# Altium schematic sheet P05_STM32MP1_PART_B_BOOT_.SchDoc(P05_STM32MP1_PART_B_BOOT_)
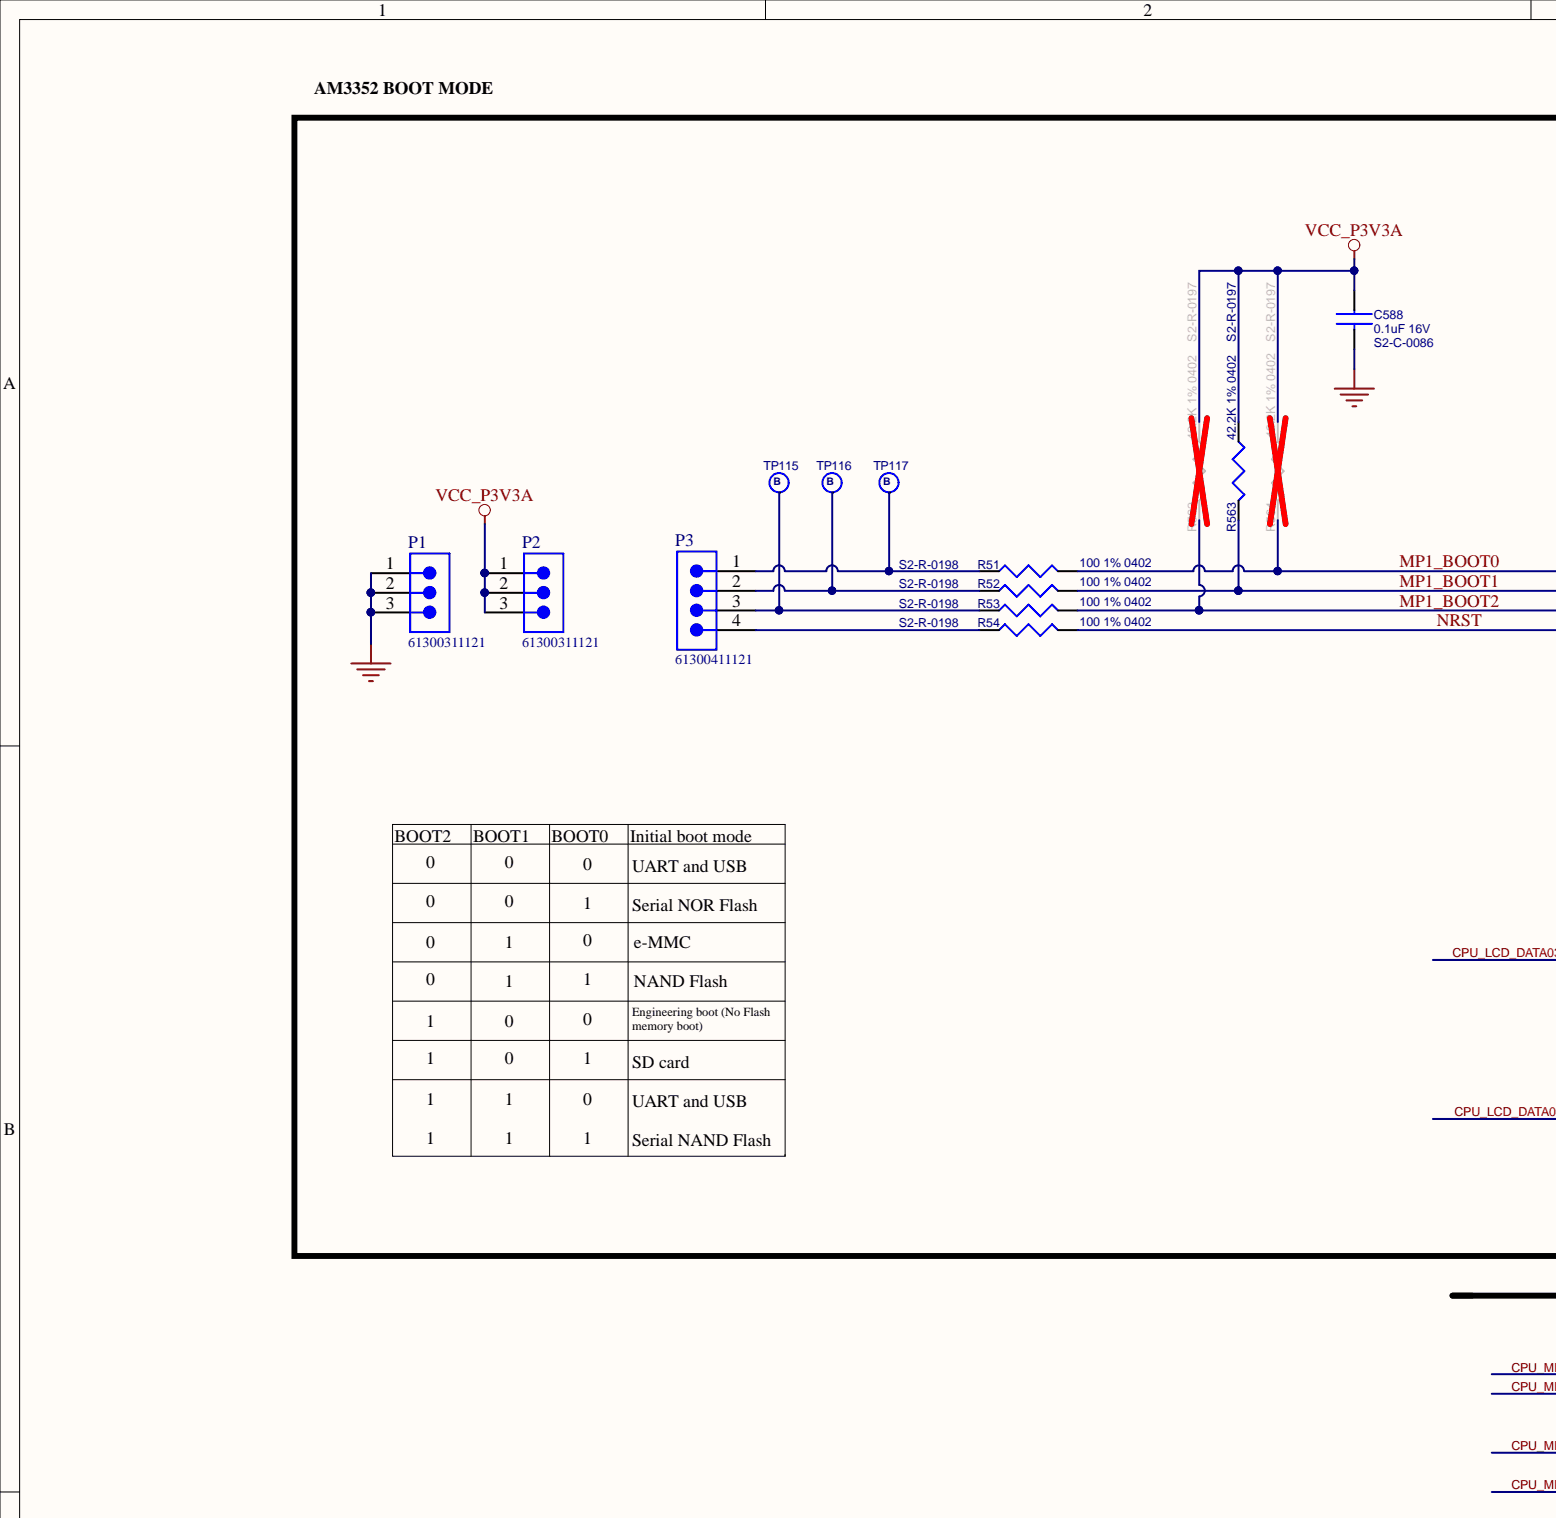
[diagram p0: P05_STM32MP1_PART_B_BOOT_.SchDoc, top left]
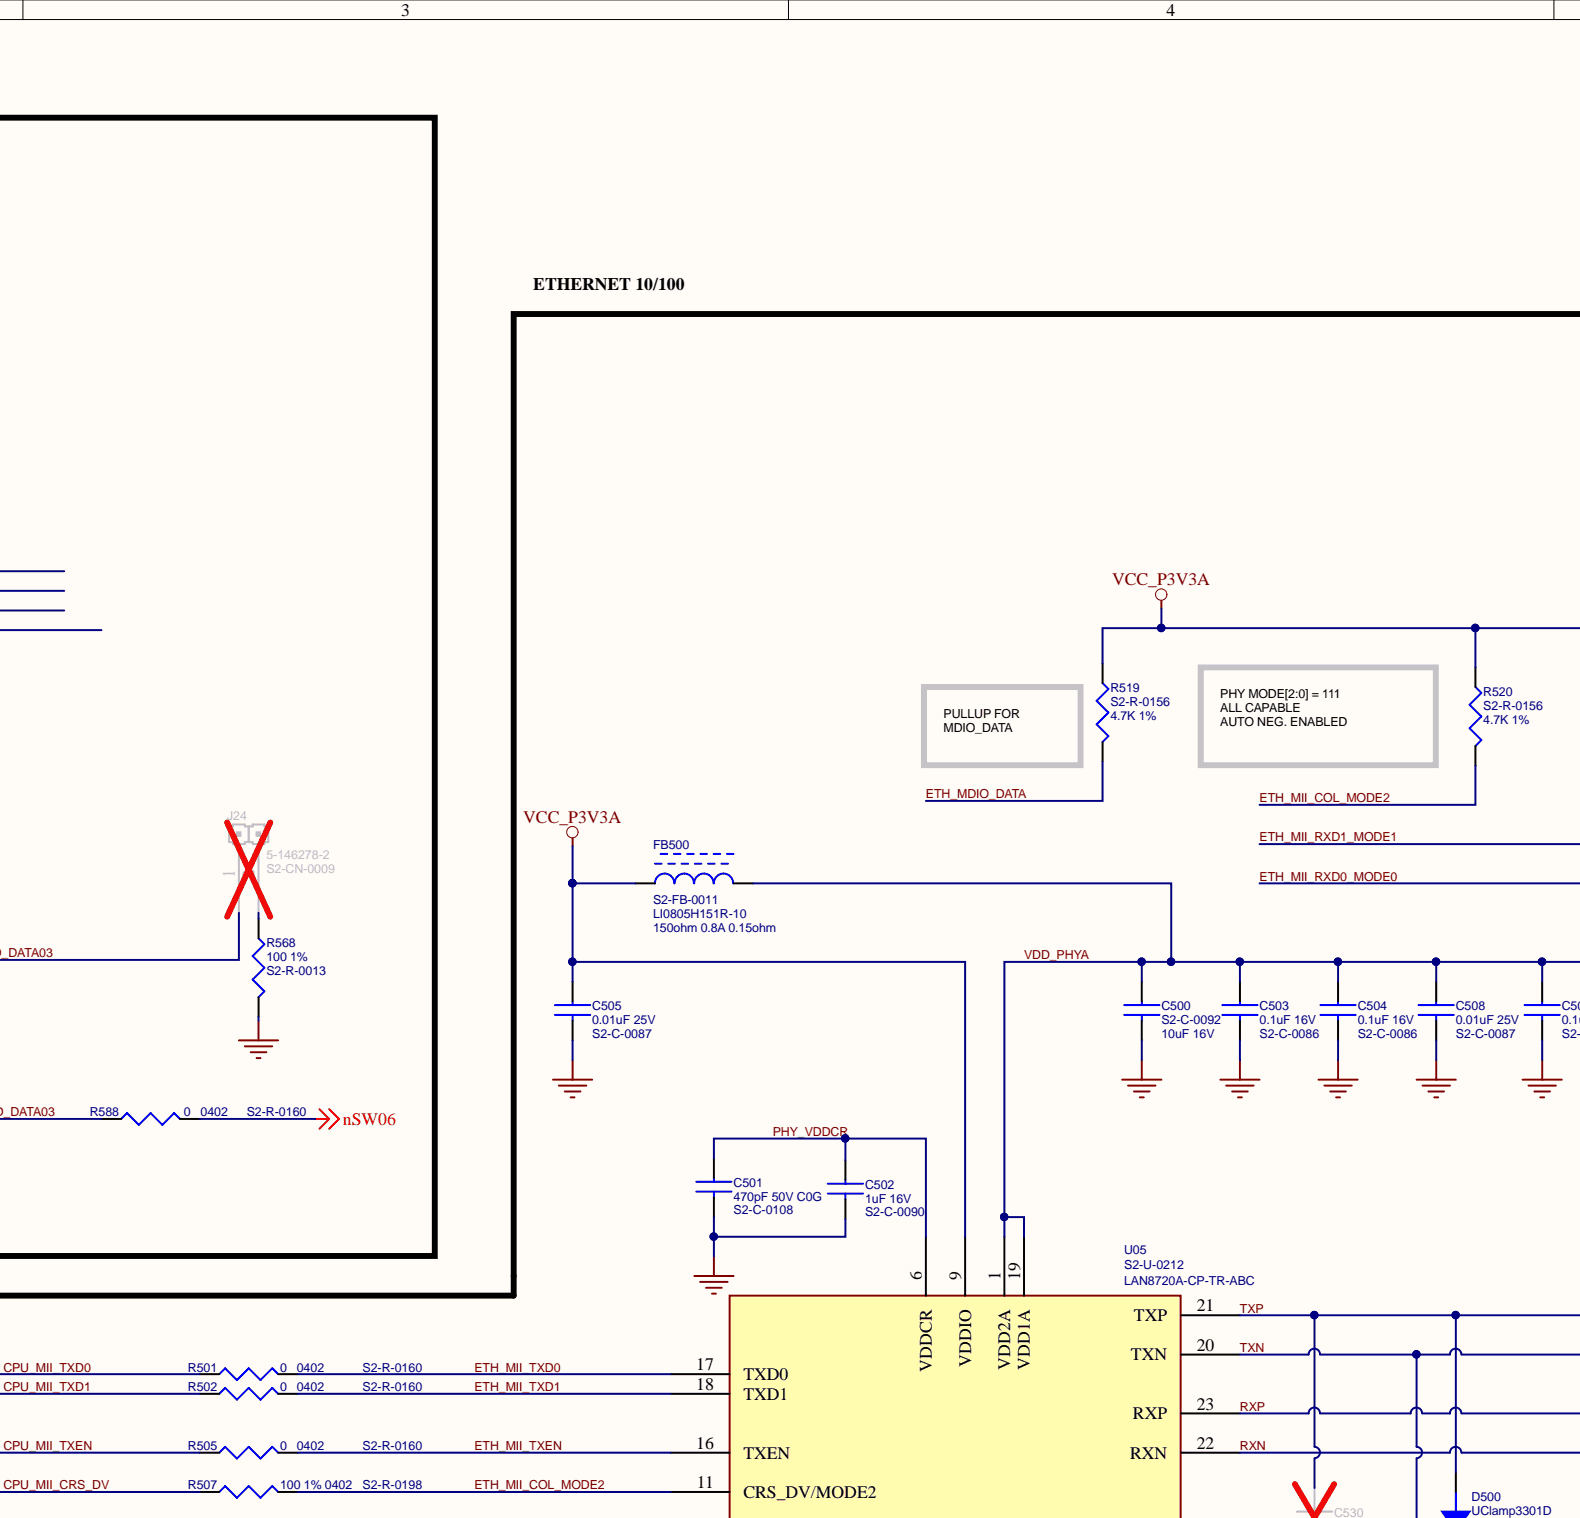
[diagram p1: P05_STM32MP1_PART_B_BOOT_.SchDoc, top centre]
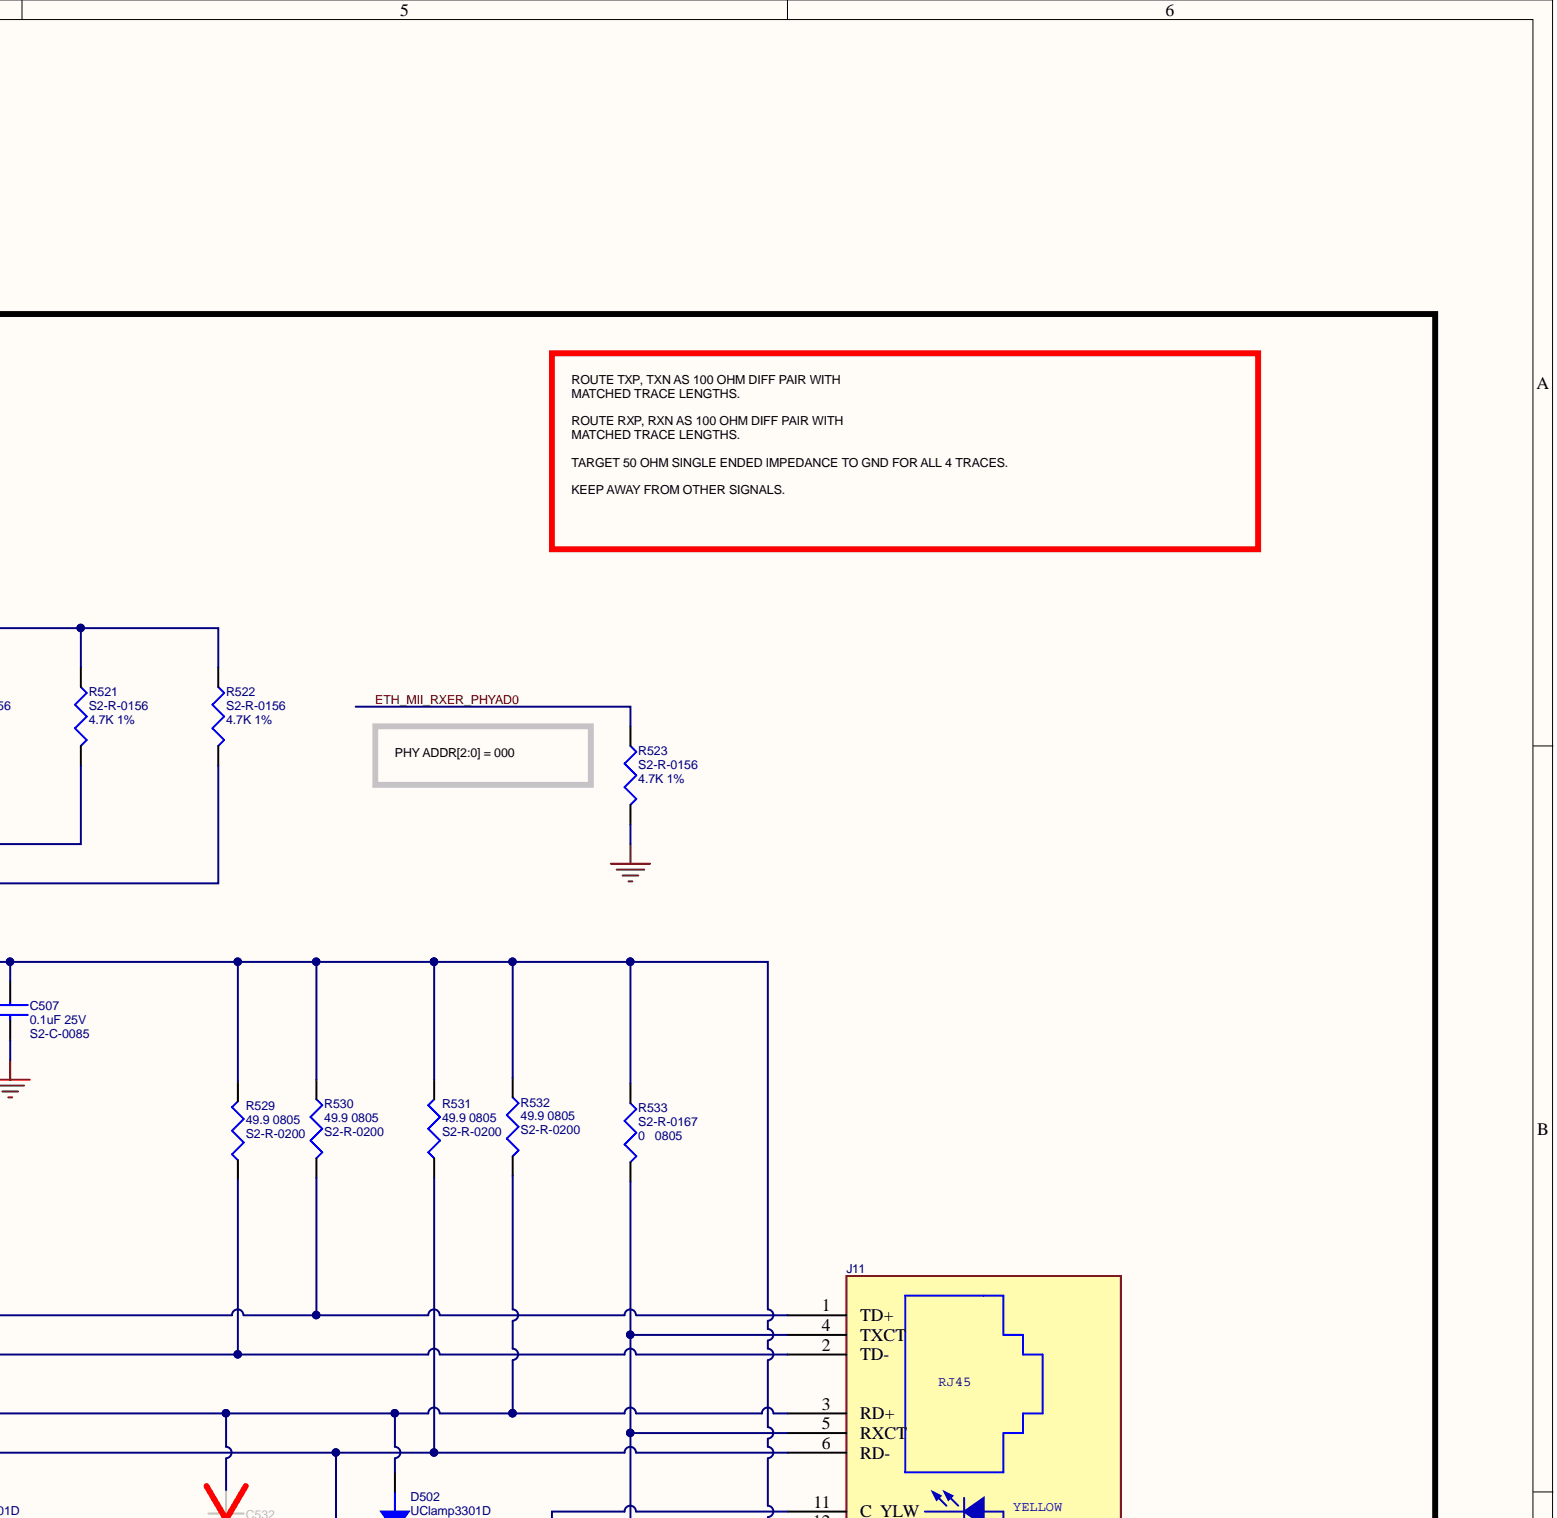
[diagram p2: P05_STM32MP1_PART_B_BOOT_.SchDoc, top right]
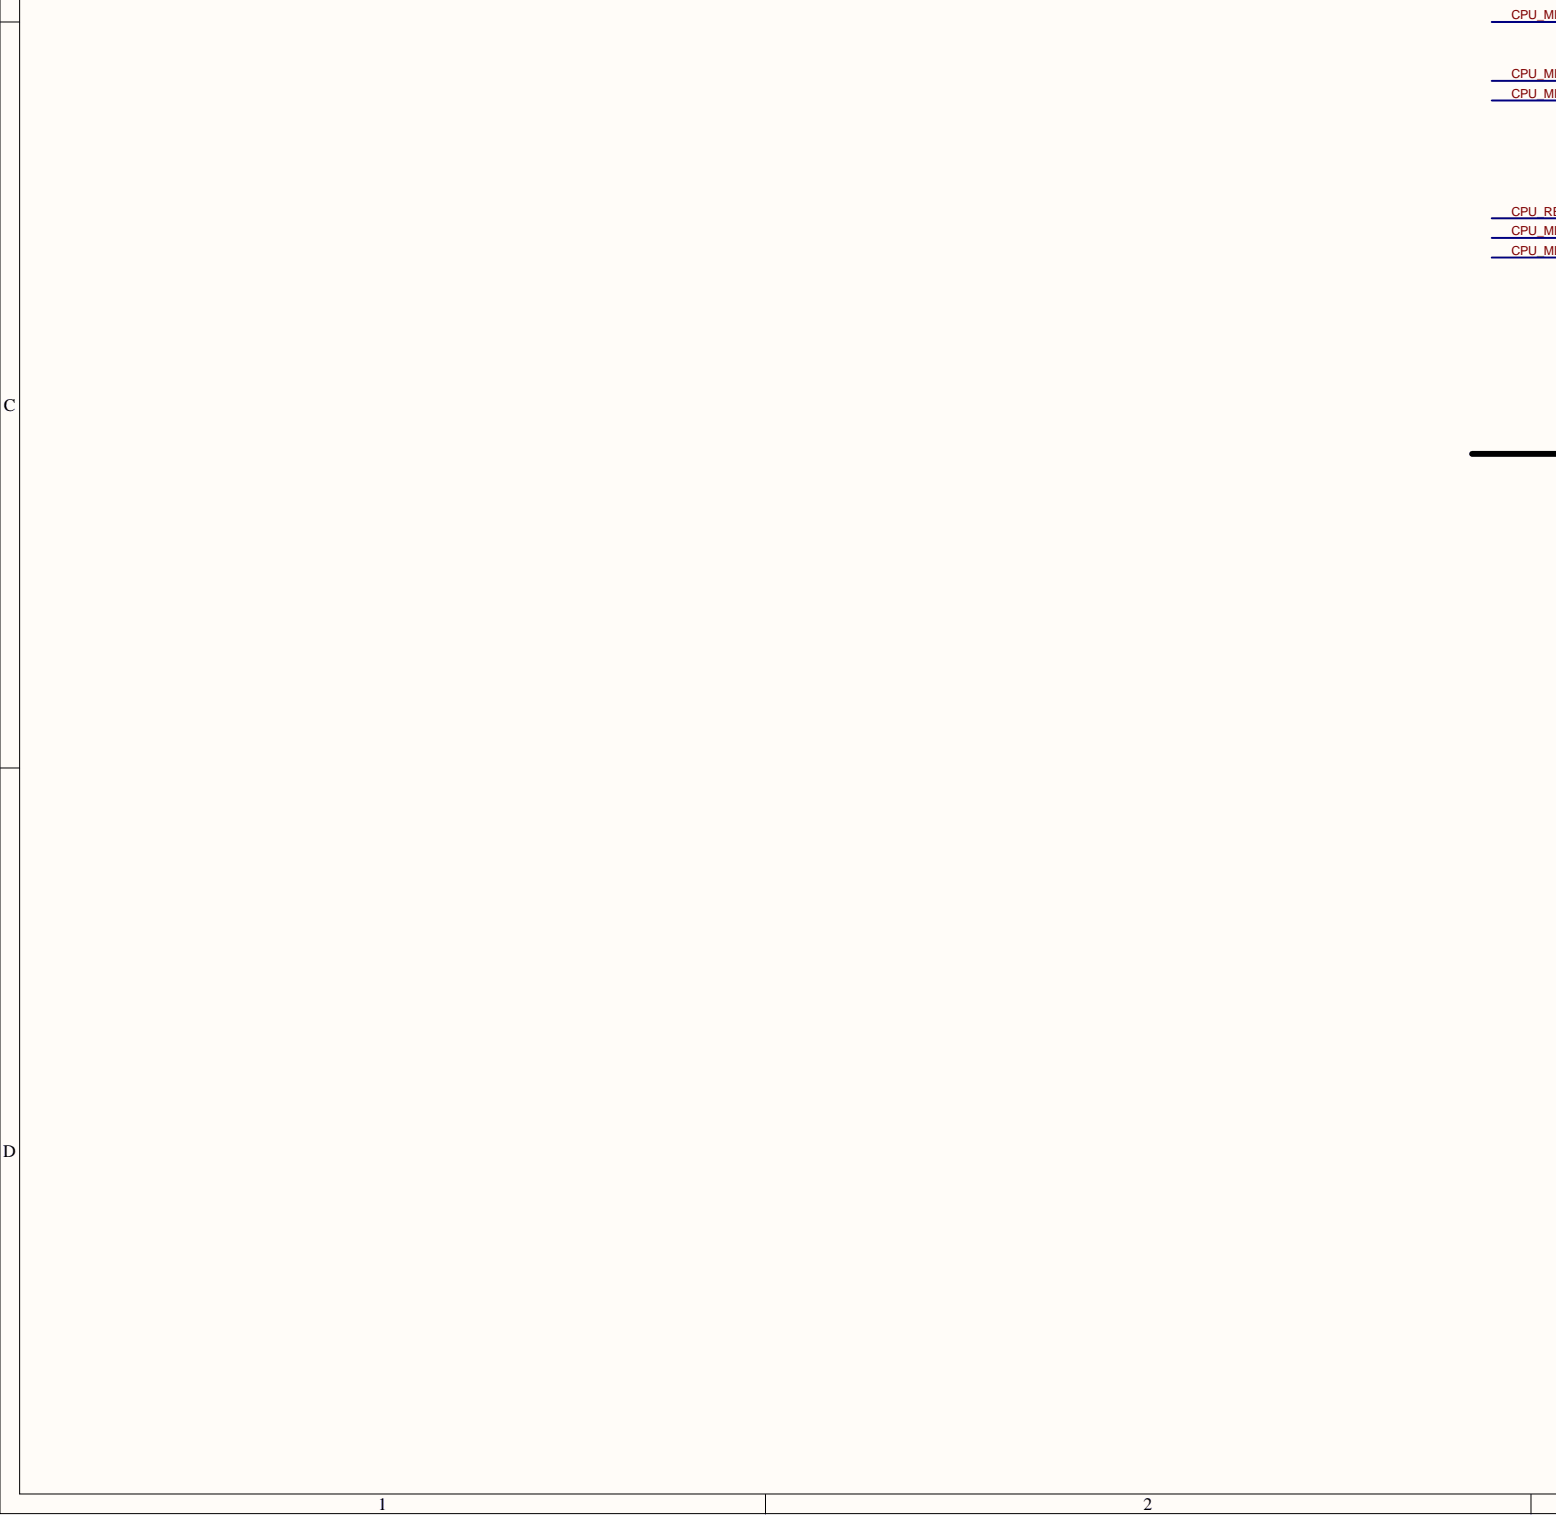
[diagram p3: P05_STM32MP1_PART_B_BOOT_.SchDoc, bottom left]
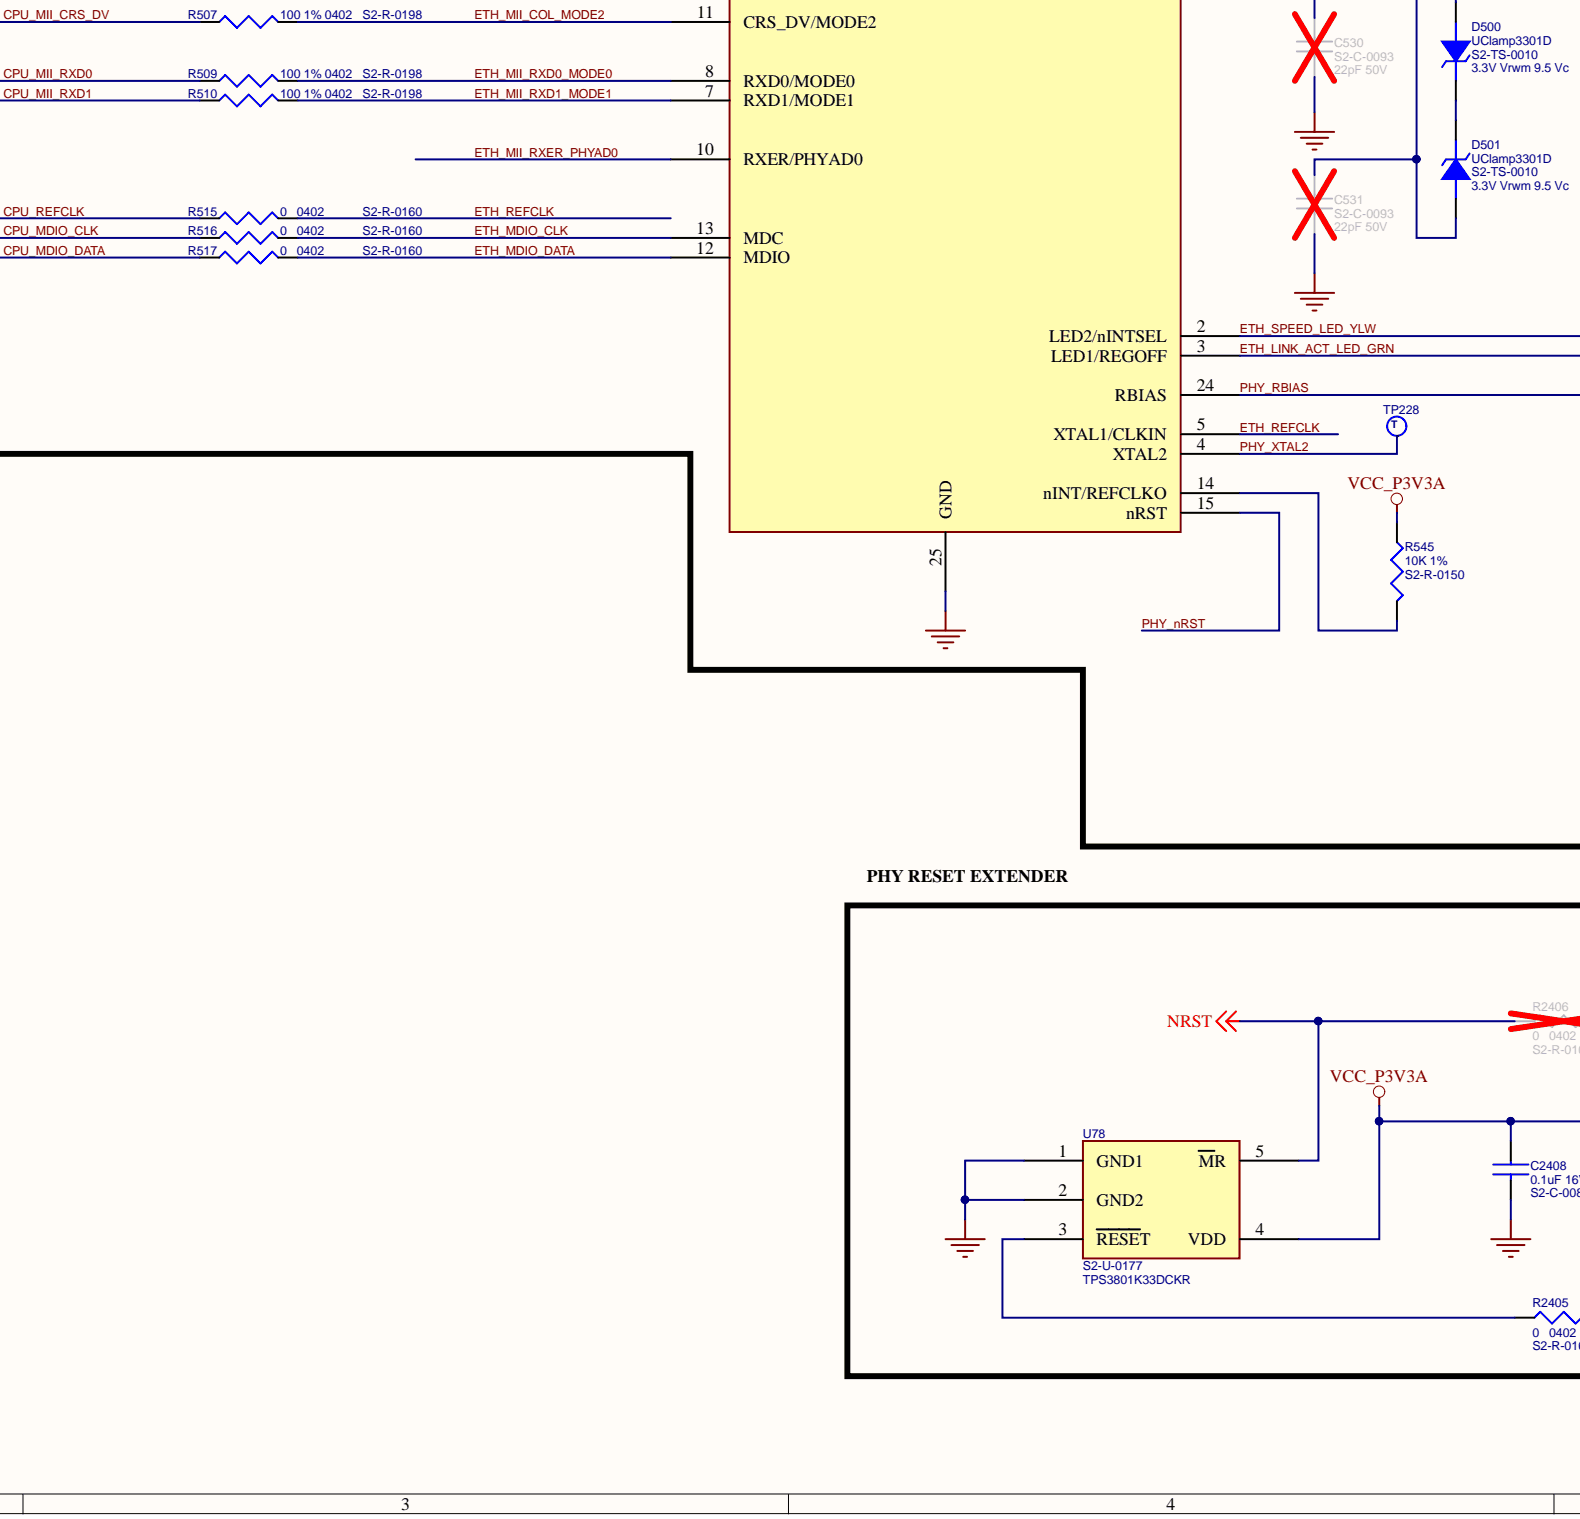
[diagram p4: P05_STM32MP1_PART_B_BOOT_.SchDoc, bottom centre]
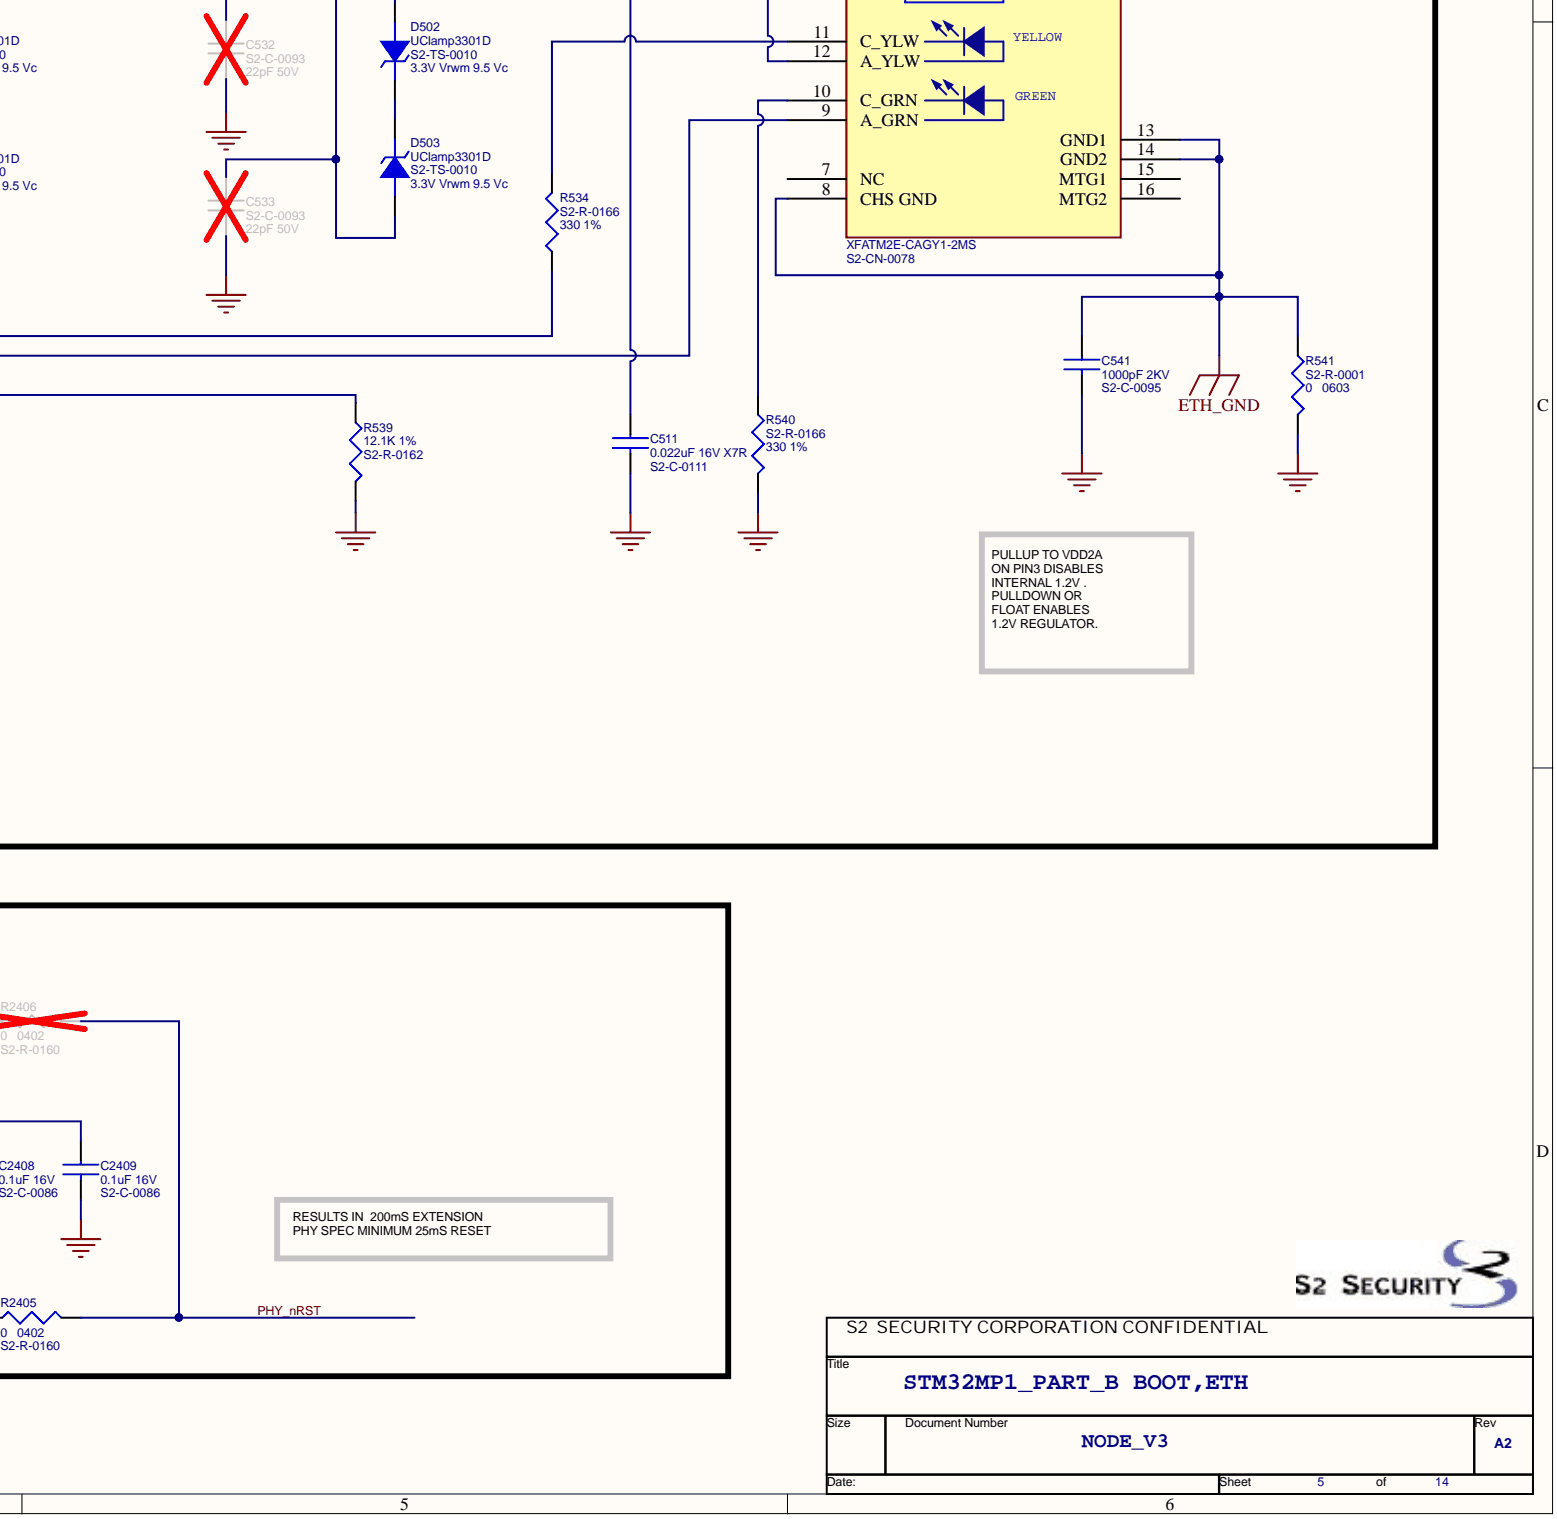
[diagram p5: P05_STM32MP1_PART_B_BOOT_.SchDoc, bottom right]

## Parts
C500  S2_PN: S2-C-0092  [CAPC2012X145N]  (hidden comment: C_10U_16V_0805)
C501  S2_PN: S2-C-0108  [CAPC1005X55N]  (hidden comment: C_470P_50V_0402_C0G)
C502  S2_PN: S2-C-0090  DESC: 1uF 16V  [CAPC1005X55N]  (hidden comment: C_1U_16V_0402)
C503  S2_PN: S2-C-0086  DESC: 0.1uF 16V  [CAPC1005X55N]  (hidden comment: C_0U1_16V_0402)
C504  S2_PN: S2-C-0086  DESC: 0.1uF 16V  [CAPC1005X55N]  (hidden comment: C_0U1_16V_0402)
C505  S2_PN: S2-C-0087  DESC: 0.01uF 25V  [CAPC1005X55N]  (hidden comment: C_0U01_25V_0402)
C507  S2_PN: S2-C-0085  DESC: 0.1uF 25V  [CAPC1608X90N]  (hidden comment: C_0U1_25V_0603)
C508  S2_PN: S2-C-0087  DESC: 0.01uF 25V  [CAPC1005X55N]  (hidden comment: C_0U01_25V_0402)
C511  S2_PN: S2-C-0111  [CAPC1005X55N]  (hidden comment: C_0U022_16V_0402)
C530  S2_PN: S2-C-0093  DESC: 22pF 50V  [CAPC1005X55N]  (hidden comment: C_22P_50V_0402_C0G)
C531  S2_PN: S2-C-0093  DESC: 22pF 50V  [CAPC1005X55N]  (hidden comment: C_22P_50V_0402_C0G)
C532  S2_PN: S2-C-0093  DESC: 22pF 50V  [CAPC1005X55N]  (hidden comment: C_22P_50V_0402_C0G)
C533  S2_PN: S2-C-0093  DESC: 22pF 50V  [CAPC1005X55N]  (hidden comment: C_22P_50V_0402_C0G)
C541  S2_PN: S2-C-0095  DESC: 1000pF 2KV  [CAPC3216X180N]  (hidden comment: C_1000P_2000V_1206)
C588  S2_PN: S2-C-0086  DESC: 0.1uF 16V  [CAPC1005X55N]  (hidden comment: C_0U1_16V_0402)
C2408  S2_PN: S2-C-0086  DESC: 0.1uF 16V  [CAPC1005X55N]  (hidden comment: C_0U1_16V_0402)
C2409  S2_PN: S2-C-0086  DESC: 0.1uF 16V  [CAPC1005X55N]  (hidden comment: C_0U1_16V_0402)
D500  S2_PN: S2-TS-0010  DESC: UClamp3301D  [SOD2613X117N]  (hidden comment: D_UCLAMP3301D)
D501  S2_PN: S2-TS-0010  DESC: UClamp3301D  [SOD2613X117N]  (hidden comment: D_UCLAMP3301D)
D502  S2_PN: S2-TS-0010  DESC: UClamp3301D  [SOD2613X117N]  (hidden comment: D_UCLAMP3301D)
D503  S2_PN: S2-TS-0010  DESC: UClamp3301D  [SOD2613X117N]  (hidden comment: D_UCLAMP3301D)
FB500  S2_PN: S2-FB-0011  DESC: LI0805H151R-10  ATTR2: 150ohm 0.8A 0.15ohm  [FB0805]  (hidden comment: FB_0A8_150OHM_0805_DC_150mOHM)
J11  S2_PN: S2-CN-0078  DESC: XFATM2E-CAGY1-2MS  [CONN_XFATM2E-CAGY1-2MS]  (hidden comment: CN_RJ45_XFATM2E-CAGY1-2MS)
J24  S2_PN: S2-CN-0009  PKG: 5-146278-2  [Conn_5-146278-2]  (hidden comment: CN_100_2POS_STR_UNSHR)
P1  61300311121  [61300311121]
P2  61300311121  [61300311121]
P3  61300411121  [61300411121]
R51  S2_PN: S2-R-0198  DESC: 100 1% 0402  [RESC1005X40N]  (hidden comment: R_100_0402)
R52  S2_PN: S2-R-0198  DESC: 100 1% 0402  [RESC1005X40N]  (hidden comment: R_100_0402)
R53  S2_PN: S2-R-0198  DESC: 100 1% 0402  [RESC1005X40N]  (hidden comment: R_100_0402)
R54  S2_PN: S2-R-0198  DESC: 100 1% 0402  [RESC1005X40N]  (hidden comment: R_100_0402)
R501  S2_PN: S2-R-0160  DESC: 0   0402  [RESC1005X40N]  (hidden comment: R_0_0402)
R502  S2_PN: S2-R-0160  DESC: 0   0402  [RESC1005X40N]  (hidden comment: R_0_0402)
R505  S2_PN: S2-R-0160  DESC: 0   0402  [RESC1005X40N]  (hidden comment: R_0_0402)
R507  S2_PN: S2-R-0198  DESC: 100 1% 0402  [RESC1005X40N]  (hidden comment: R_100_0402)
R509  S2_PN: S2-R-0198  DESC: 100 1% 0402  [RESC1005X40N]  (hidden comment: R_100_0402)
R510  S2_PN: S2-R-0198  DESC: 100 1% 0402  [RESC1005X40N]  (hidden comment: R_100_0402)
R515  S2_PN: S2-R-0160  DESC: 0   0402  [RESC1005X40N]  (hidden comment: R_0_0402)
R516  S2_PN: S2-R-0160  DESC: 0   0402  [RESC1005X40N]  (hidden comment: R_0_0402)
R517  S2_PN: S2-R-0160  DESC: 0   0402  [RESC1005X40N]  (hidden comment: R_0_0402)
R519  S2_PN: S2-R-0156  DESC: 4.7K 1%  [RESC1005X40N]  (hidden comment: R_4K7_0402)
R520  S2_PN: S2-R-0156  DESC: 4.7K 1%  [RESC1005X40N]  (hidden comment: R_4K7_0402)
R521  S2_PN: S2-R-0156  DESC: 4.7K 1%  [RESC1005X40N]  (hidden comment: R_4K7_0402)
R522  S2_PN: S2-R-0156  DESC: 4.7K 1%  [RESC1005X40N]  (hidden comment: R_4K7_0402)
R523  S2_PN: S2-R-0156  DESC: 4.7K 1%  [RESC1005X40N]  (hidden comment: R_4K7_0402)
R529  S2_PN: S2-R-0200  DESC: 49.9 0805  [RESC2012X50N]  (hidden comment: R_49R9_0805)
R530  S2_PN: S2-R-0200  DESC: 49.9 0805  [RESC2012X50N]  (hidden comment: R_49R9_0805)
R531  S2_PN: S2-R-0200  DESC: 49.9 0805  [RESC2012X50N]  (hidden comment: R_49R9_0805)
R532  S2_PN: S2-R-0200  DESC: 49.9 0805  [RESC2012X50N]  (hidden comment: R_49R9_0805)
R533  S2_PN: S2-R-0167  DESC: 0   0805  [RESC2012X50N]  (hidden comment: R_0_0805)
R534  S2_PN: S2-R-0166  DESC: 330 1%  [RESC1005X40N]  (hidden comment: R_330_0402)
R539  S2_PN: S2-R-0162  DESC: 12.1K 1%  [RESC1005X40N]  (hidden comment: R_12K1_0402)
R540  S2_PN: S2-R-0166  DESC: 330 1%  [RESC1005X40N]  (hidden comment: R_330_0402)
R541  S2_PN: S2-R-0001  DESC: 0   0603  [RESC1608X55N]  (hidden comment: R_0_0603)
R545  S2_PN: S2-R-0150  DESC: 10K 1%  [RESC1005X40N]  (hidden comment: R_10K_0402)
R562  DESC: 42.2K 1% 0402  [RESC1005X40N]  (hidden comment: R_42K2_0402)
R563  DESC: 42.2K 1% 0402  [RESC1005X40N]  (hidden comment: R_42K2_0402)
R564  DESC: 42.2K 1% 0402  [RESC1005X40N]  (hidden comment: R_42K2_0402)
R568  S2_PN: S2-R-0013  DESC: 100 1%  [RESC1608X55N]  (hidden comment: R_100_0603)
R588  S2_PN: S2-R-0160  DESC: 0   0402  [RESC1005X40N]  (hidden comment: R_0_0402)
R2405  S2_PN: S2-R-0160  DESC: 0   0402  [RESC1005X40N]  (hidden comment: R_0_0402)
R2406  S2_PN: S2-R-0160  DESC: 0   0402  [RESC1005X40N]  (hidden comment: R_0_0402)
TP115  TP_SMT_TEST_POINT_BOTTOM  [TP35_SMT]  (value not drawn)
TP116  TP_SMT_TEST_POINT_BOTTOM  [TP35_SMT]  (value not drawn)
TP117  TP_SMT_TEST_POINT_BOTTOM  [TP35_SMT]  (value not drawn)
TP228  TP_SMT_TEST_POINT_TOP  [TP35_SMT]  (value not drawn)
U05  S2_PN: S2-U-0212  DESC: LAN8720A-CP-TR-ABC  [QFN50P400X400X100-25N]  (hidden comment: U_LAN8720A_CP)
U78  S2_PN: S2-U-0177  DESC: TPS3801K33DCKR  [SOT65x210x140x110-5N]  (hidden comment: U_TPS3801K33DCKR_SUPERVISOR)

## Nets
ETH_GND: C541.1 J11.8(CHS_GND) J11.13(GND1) J11.14(GND2) R541.1
GND: C500.2 C501.2 C502.2 C503.2 C504.2 C505.2 C507.2 C508.2 C511.2 C530.2 C531.2 C532.2 C533.2 C541.2 C588.2 C2408.2 C2409.2 P1.1 P1.2 P1.3 R523.2 R539.2 R540.2 R541.2 R568.2 U05.25(GND) U78.1(GND1) U78.2(GND2)
nSW06: R588.2
VCC_P3V3A: C505.1 C588.1 C2408.1 C2409.1 FB500.1 P2.1 P2.2 P2.3 R519.1 R520.1 R521.1 R522.1 R545.1 R562.1 R563.1 R564.1 U05.9(VDDIO) U78.4(VDD)
CPU_LCD_DATA03: J24.1 R588.1
CPU_MDIO_CLK: R516.1
CPU_MDIO_DATA: R517.1
CPU_MII_CRS_DV: R507.1
CPU_MII_RXD0: R509.1
CPU_MII_RXD1: R510.1
CPU_MII_TXD0: R501.1
CPU_MII_TXD1: R502.1
CPU_MII_TXEN: R505.1
CPU_REFCLK: R515.1
ETH_LINK_ACT_LED_GRN: J11.9(A_GRN) U05.3(LED1/REGOFF)
ETH_MDIO_CLK: R516.2 U05.13(MDC)
ETH_MDIO_DATA: R517.2 R519.2 U05.12(MDIO)
ETH_MII_COL_MODE2: R507.2 R520.2 U05.11(CRS_DV/MODE2)
ETH_MII_RXD0_MODE0: R509.2 R522.2 U05.8(RXD0/MODE0)
ETH_MII_RXD1_MODE1: R510.2 R521.2 U05.7(RXD1/MODE1)
ETH_MII_RXER_PHYAD0: R523.1 U05.10(RXER/PHYAD0)
ETH_MII_TXD0: R501.2 U05.17(TXD0)
ETH_MII_TXD1: R502.2 U05.18(TXD1)
ETH_MII_TXEN: R505.2 U05.16(TXEN)
ETH_REFCLK: R515.2 U05.5(XTAL1/CLKIN)
ETH_SPEED_LED_YLW: R534.2 U05.2(LED2/nINTSEL)
MP1_BOOT0: R51.2 R564.2
MP1_BOOT1: R52.2 R563.2
MP1_BOOT2: R53.2 R562.2
NRST: R54.2 R2406.1 U78.5(MR)
PHY_nRST: R2405.2 R2406.2 U05.15(nRST)
PHY_RBIAS: R539.1 U05.24(RBIAS)
PHY_VDDCR: C501.1 C502.1 U05.6(VDDCR)
PHY_XTAL2: TP228.1 U05.4(XTAL2)
RXN: C533.1 D503.1 J11.6(RD-) R531.2 U05.22(RXN)
RXP: C532.1 D502.1 J11.3(RD+) R532.2 U05.23(RXP)
TXN: C531.1 D501.1 J11.2(TD-) R529.2 U05.20(TXN)
TXP: C530.1 D500.1 J11.1(TD+) R530.2 U05.21(TXP)
VDD_PHYA: C500.1 C503.1 C504.1 C507.1 C508.1 FB500.2 J11.12(A_YLW) R529.1 R530.1 R531.1 R532.1 R533.1 U05.1(VDD2A) U05.19(VDD1A)
NetC511_1: C511.1 J11.4(TXCT) J11.5(RXCT) R533.2
NetD500_2: D500.2 D501.2
NetD502_2: D502.2 D503.2
NetJ11_10: J11.10(C_GRN) R540.1
NetJ11_11: J11.11(C_YLW) R534.1
NetJ24_2: J24.2 R568.1
NetP3_1: P3.1 R51.1 TP117.1
NetP3_2: P3.2 R52.1 TP116.1
NetP3_3: P3.3 R53.1 TP115.1
NetP3_4: P3.4 R54.1
NetR545_2: R545.2 U05.14(nINT/REFCLKO)
NetR2405_1: R2405.1 U78.3(RESET)

Not connected: J11 7(NC) 15(MTG1) 16(MTG2)

## Library data of the parts
C500 = C_10U_16V_0805: PKG: CC0805IPC; DESC: 10uF 16V; ATTR: X5R 10%; Library Name: NODE_V2_M1.SCHLIB; Component Kind: Standard
C501 = C_470P_50V_0402_C0G: PKG: CC0402IPC; DESC: 470pF 50V C0G; ATTR: C0G 5%; Library Name: NODE_V2_M1.SCHLIB; Component Kind: Standard
C502 = C_1U_16V_0402: PKG: CC0402IPC; ATTR: X5R 10%; Library Name: NODE_V2_M1.SCHLIB; Component Kind: Standard
C503 C504 C588 C2408 C2409 = C_0U1_16V_0402: PKG: CC0402IPC; ATTR: X7R 10%; Library Name: NODE_V2_M1.SCHLIB; Component Kind: Standard
C505 C508 = C_0U01_25V_0402: PKG: CC0402IPC; ATTR: X7R 10%; Library Name: NODE_V2_M1.SCHLIB; Component Kind: Standard
C507 = C_0U1_25V_0603: PKG: CC0603IPC; ATTR: X7R 10%; Library Name: NODE_V2_M1.SCHLIB; Component Kind: Standard
C511 = C_0U022_16V_0402: PKG: CC0402IPC; DESC: 0.022uF 16V X7R; ATTR: X7R 10%; Library Name: NODE_V2_M1.SCHLIB; Component Kind: Standard
C530-C533 = C_22P_50V_0402_C0G: PKG: CC0402IPC; ATTR: C0G 5%; Library Name: NODE_V2_M1.SCHLIB; Component Kind: Standard
C541 = C_1000P_2000V_1206: PKG: CC1206IPC; ATTR: X7R 10%; Library Name: NODE_V2_M1.SCHLIB; Component Kind: Standard
D500-D503 = D_UCLAMP3301D: PKG: SOD-323; ATTR2: 3.3V Vrwm 9.5 Vc; ATTR: TVS 3.3V REV 9.5V CLAMP SOD323; Library Name: NODE_V2_M1.SCHLIB; Component Kind: Standard
FB500 = FB_0A8_150OHM_0805_DC_150mOHM: PKG: CC0805IPC; ATTR: FERRITE 800MA 150 OHM 0805, 0.15 OHM DC; Library Name: NODE_V2_M1.SCHLIB; Component Kind: Standard
J11 = CN_RJ45_XFATM2E-CAGY1-2MS: PKG: TH_CONN14A_RJ45; ATTR: RJ45 W/ LED, MAG, NO EMI FINGERS; Library Name: NODE_V2_M1.SCHLIB; Component Kind: Standard
J24 = CN_100_2POS_STR_UNSHR: ATTR: HEADER MALE 2POS 0.100" STRAIGHT UNSHROUDED; Library Name: NODE_V2_M1.SCHLIB; DESC: 5-146278-2; Component Kind: Standard
P1 P2 = 61300311121: WR-PHD Pin Header, THT, pitch 2.54mm, Single Row, Vertical, 3p; Manufacturer: Wurth Elektronik; Working Voltage: 250VAC; Withstanding Voltage: 500VAC; Rated Current: 3A; Pin Number: 3; Contact Resistance: 20mOhm; Row Number: Single; Published Date: May 2020; Pitch: 2.54mm; Packaging: Bag; Orientation: Vertical; Operating Temperature: -40 to +105degC; Mounting Technology: THT; Manufacturer Part Number: 61300311121; Library Name: Altium_WR-PHD (rev20a).IntLib; Gender: Pin Header; ComponentLink2URL: https://www.we-online.com/catalog/datasheet/61300311121.pdf; ComponentLink2Description: Datasheet; ComponentLink1URL: http://www.we-online.de; ComponentLink1Description: Manufacturer; Component Kind: Standard
P3 = 61300411121: WR-PHD Pin Header, THT, pitch 2.54mm, Single Row, Vertical, 4p; Manufacturer: Wurth Elektronik; Working Voltage: 250VAC; Withstanding Voltage: 500VAC; Rated Current: 3A; Pin Number: 4; Contact Resistance: 20mOhm; Row Number: Single; Published Date: May 2020; Pitch: 2.54mm; Packaging: Bag; Orientation: Vertical; Operating Temperature: -40 to +105degC; Mounting Technology: THT; Manufacturer Part Number: 61300411121; Library Name: Altium_WR-PHD (rev20a).IntLib; Gender: Pin Header; ComponentLink2URL: https://www.we-online.com/catalog/datasheet/61300411121.pdf; ComponentLink2Description: Datasheet; ComponentLink1URL: http://www.we-online.de; ComponentLink1Description: Manufacturer; Component Kind: Standard
R51-R54 R507 R509 R510 = R_100_0402: PKG: CC0402IPC; ATTR: 1/16W; Library Name: NODE_V2_M1.SCHLIB; Component Kind: Standard
R501 R502 R505 R515-R517 R588 R2405 R2406 = R_0_0402: PKG: CC0402IPC; ATTR: 1/16W  50mohm MAX; Library Name: NODE_V2_M1.SCHLIB; Component Kind: Standard
R519-R523 = R_4K7_0402: PKG: CC0402IPC; ATTR: 1/16W; Library Name: NODE_V2_M1.SCHLIB; Component Kind: Standard
R529-R532 = R_49R9_0805: PKG: CC0805IPC; ATTR: RES 49.9 OHM 1/10W 1% 0805; Library Name: NODE_V2_M1.SCHLIB; Component Kind: Standard
R533 = R_0_0805: PKG: CC0805IPC; ATTR: 1/10W 50mohm MAX; Library Name: NODE_V2_M1.SCHLIB; Component Kind: Standard
R534 R540 = R_330_0402: PKG: CC0402IPC; ATTR: 1/16W; Library Name: NODE_V2_M1.SCHLIB; Component Kind: Standard
R539 = R_12K1_0402: PKG: CC0402IPC; ATTR: 1/16W; Library Name: NODE_V2_M1.SCHLIB; Component Kind: Standard
R541 = R_0_0603: PKG: CC0603IPC; ATTR: 1/10W 50mohm MAX; Library Name: NODE_V2_M1.SCHLIB; Component Kind: Standard
R545 = R_10K_0402: PKG: CC0402IPC; ATTR: 1/16W; Library Name: NODE_V2_M1.SCHLIB; Component Kind: Standard
R562-R564 = R_42K2_0402: S2_PN: S2-R-0197; PKG: CC0402IPC; ATTR: 1/16W; Library Name: NODE_V2_M1.SCHLIB; Component Kind: Standard
R568 = R_100_0603: PKG: CC0603IPC; ATTR: 1/10W; Library Name: NODE_V2_M1.SCHLIB; Component Kind: Standard
TP115-TP117 = TP_SMT_TEST_POINT_BOTTOM: S2_PN: S2-TP-0003; PKG: TEST-PT-TPB; DESC: TEST POINT; ATTR: SMT BOTTOM SIDE TEST POINT; Library Name: NODE_V2_M1.SCHLIB; DNP: DNP; Component Kind: Standard
TP228 = TP_SMT_TEST_POINT_TOP: S2_PN: S2-TP-0002; PKG: TEST-PT-TPT; DESC: TEST POINT; ATTR: SMT TOP SIDE TEST POINT; Library Name: NODE_V2_M1.SCHLIB; DNP: DNP; Component Kind: Standard
U05 = U_LAN8720A_CP: PKG: QFN-24_4X4MM; ATTR: ETH PHY 10/100 RMII; Library Name: NODE_V2_M1.SCHLIB; Component Kind: Standard
U78 = U_TPS3801K33DCKR_SUPERVISOR: PKG: SC70-5; ATTR: IC VOLT SUPERVISOR 2.93V W MR SC70-5; Library Name: NODE_V2_M1.SCHLIB; Component Kind: Standard
